# Altium schematic sheet DB10005_TopLevel.SchDoc(DB10005_TopLevel)
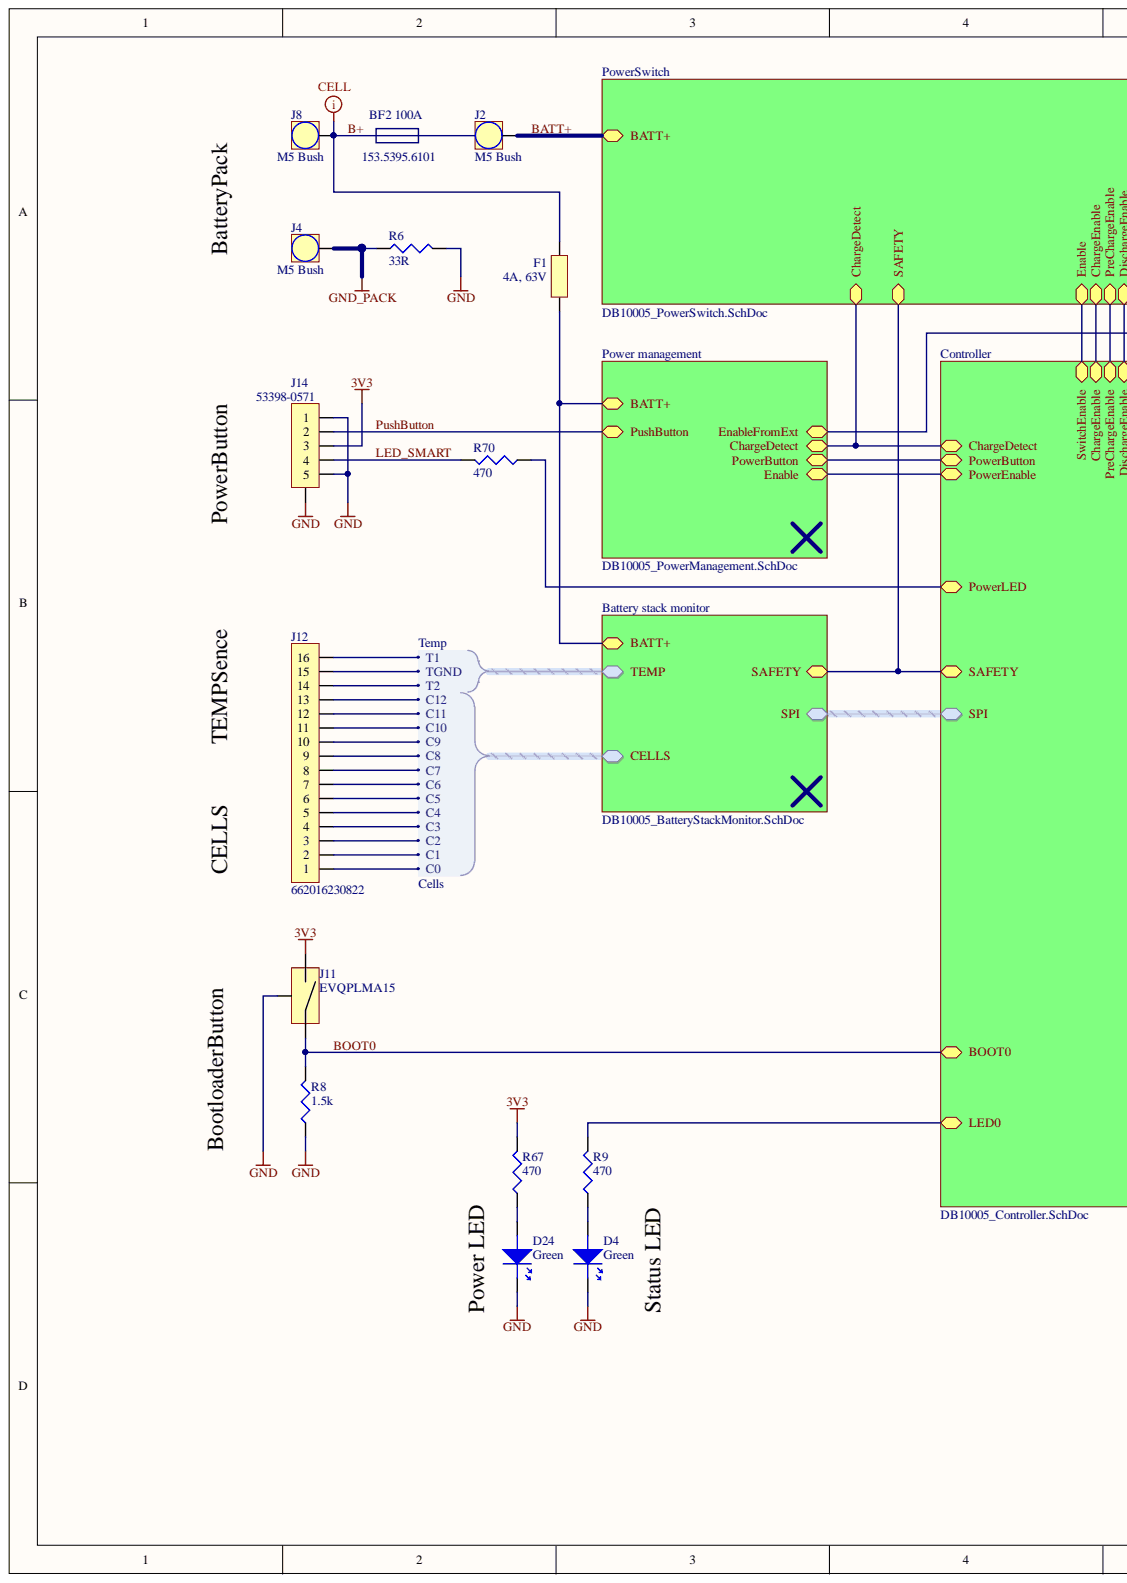
[diagram p0: DB10005_TopLevel.SchDoc, left]
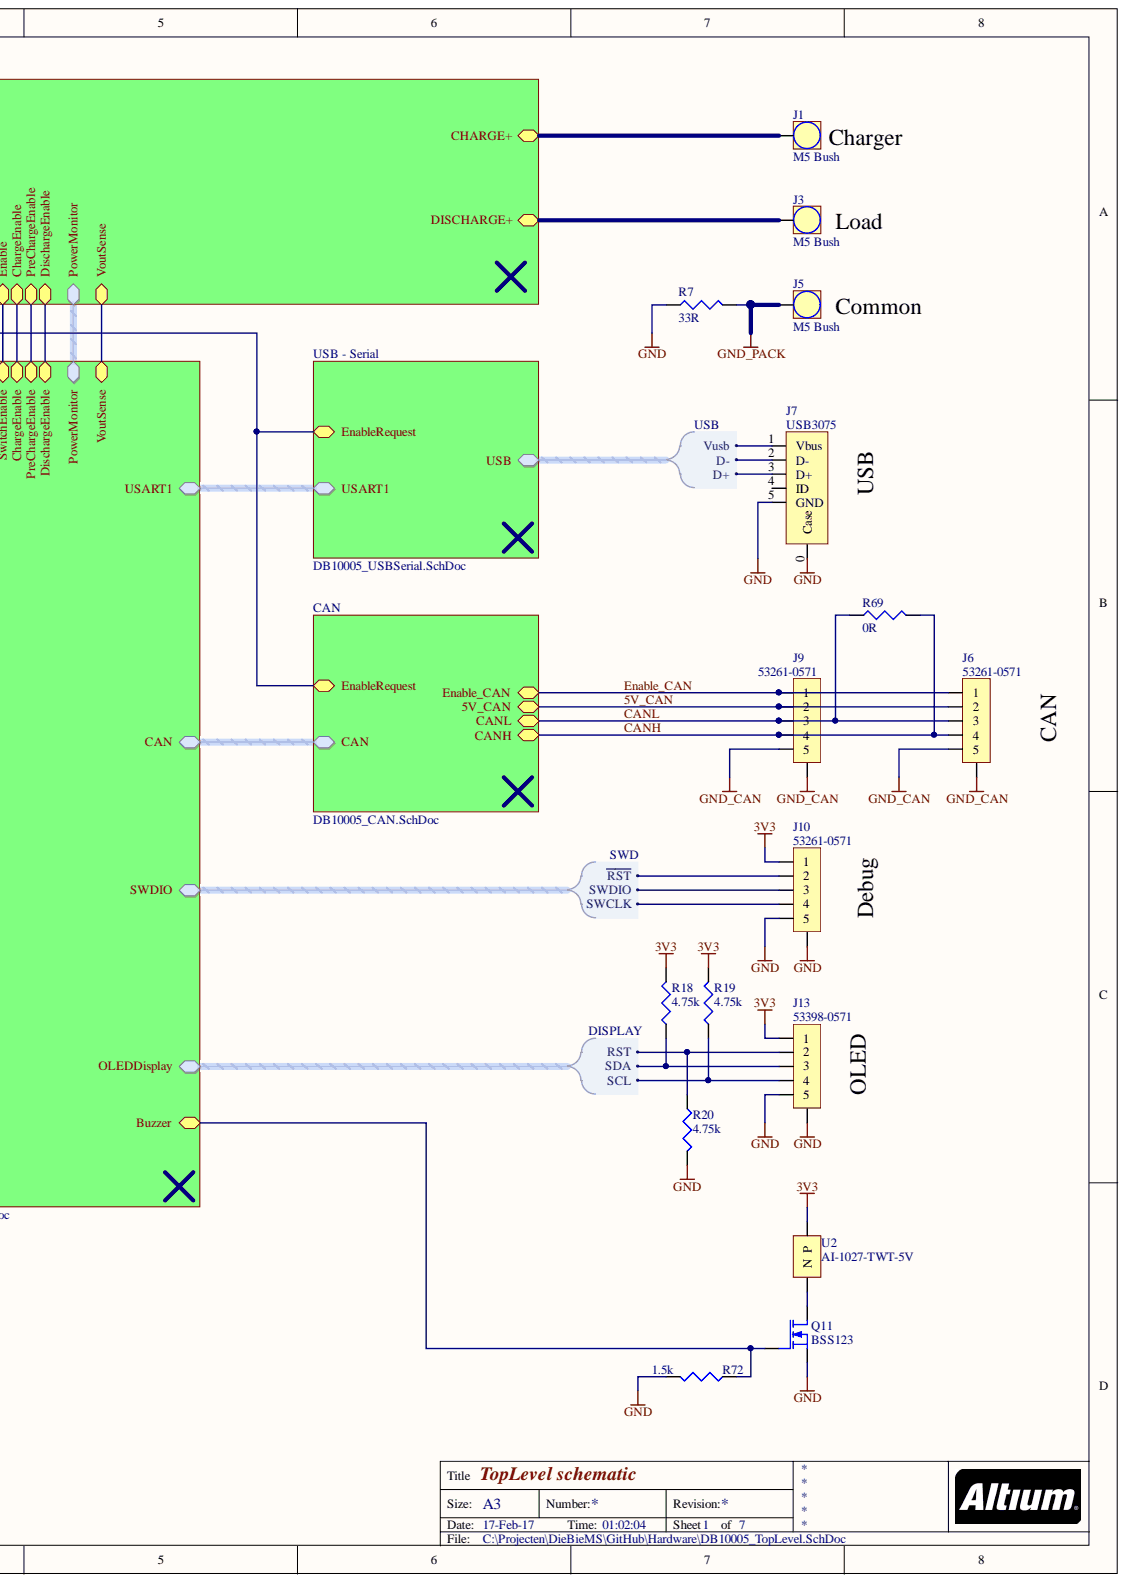
[diagram p1: DB10005_TopLevel.SchDoc, right]

## Parts
D4  Green  [D-0805]
D24  Green  [D-0805]
F1  4A, 63V  [F1206]
J1  M5 Bush  [7460408_HAL Surface]
J2  M5 Bush  [7460408_HAL Surface]
J3  M5 Bush  [7460408_HAL Surface]
J4  M5 Bush  [7460408_HAL Surface]
J5  M5 Bush  [7460408_HAL Surface]
J6  53261-0571  [53261-0571]
J7  USB3075  [USB3075 - NOSLOT]
J8  M5 Bush  [7460408_HAL Surface]
J9  53261-0571  [53261-0571]
J10  53261-0571  [53261-0571]
J11  EVQPLMA15  [EVQPLM]
J12  662016230822  [662016230822]
J13  53398-0571  [53398-0571]
J14  53398-0571  [53398-0571]
Q11  BSS123  [SOT-23]
R6  33R  [2512]
R7  33R  [2512]
R8  1.5k  [0402]
R9  470  [0402]
R18  4.75k  [0402]
R19  4.75k  [0402]
R20  4.75k  [0402]
R67  470  [0402]
R69  0R  [0805]
R70  470  [0402]
R72  1.5k  [0402]
U2  AI-1027-TWT-5V  [AI-1027-TWT]

## Nets
3V3: J10.1 J11.2 J13.1 J14.3 R18.2 R19.2 R67.2 U2.P
GND: D4.K D24.K J7.0(Case) J7.5(GND) J10.0 J10.5 J11.0 J13.0 J13.5 J14.0 J14.1 J14.5 Q11.S R6.2 R7.2 R8.1 R20.2 R72.2
GND_CAN: J6.0 J6.5 J9.0 J9.5
GND_PACK: J4.1 J5.1 R6.1 R7.1
5V_CAN: J6.2 J9.2
B+: F1.2 J8.1
BATT+: J2.1
BOOT0: J11.1 R8.2
CANH: J6.4 J9.4 R69.2
CANL: J6.3 J9.3 R69.1
ENABLE_CAN: J6.1 J9.1
LED_SMART: J14.4 R70.2
PUSHBUTTON: J14.2
NetD4_A: D4.A R9.1
NetD24_A: D24.A R67.1
NetF1_1: F1.1
NetJ1_1: J1.1
NetJ3_1: J3.1
NetJ7_1: J7.1(Vbus)
NetJ7_2: J7.2(D-)
NetJ7_3: J7.3(D+)
NetJ7_4: J7.4(ID)
NetJ10_2: J10.2
NetJ10_3: J10.3
NetJ10_4: J10.4
NetJ12_1: J12.1
NetJ12_2: J12.2
NetJ12_3: J12.3
NetJ12_4: J12.4
NetJ12_5: J12.5
NetJ12_6: J12.6
NetJ12_7: J12.7
NetJ12_8: J12.8
NetJ12_9: J12.9
NetJ12_10: J12.10
NetJ12_11: J12.11
NetJ12_12: J12.12
NetJ12_13: J12.13
NetJ12_14: J12.14
NetJ12_15: J12.15
NetJ12_16: J12.16
NetJ13_2: J13.2 R20.1
NetJ13_3: J13.3 R18.1
NetJ13_4: J13.4 R19.1
NetQ11_D: Q11.D U2.N
NetQ11_G: Q11.G R72.1
NetR9_2: R9.2
NetR70_1: R70.1
CAN.RXD:
CAN.TXD:
CHARGEDETECT:
CHARGEENABLE:
DISCHARGEENABLE:
ENABLEREQUEST:
N00290:
N00291:
N00292:
N00293:
N00294:
N00295:
N00296:
N00297:
N00298:
N00299:
N00300:
N00301:
N00302:
POWERBUTTON:
POWERENABLE:
POWERMONITOR.INT:
POWERMONITOR.SCL:
POWERMONITOR.SDA:
PRECHARGEENABLE:
SAFETY:
SPI.MISO:
SPI.MOSI:
SPI.~{SS}:
SPI.SCK:
SWITCHENABLE:
USART1.RXD:
USART1.TXD:
VOUTSENSE:

## Library data of the parts
D4 D24 = KP-2012SGC: Led, Green, Li:12mcd, Vf:2.2V, If:0.02A; Brand: KingBright; Case Style: 0805; Forward Current: 0.02A; Forward Voltage: 2.2V; Luminous Intensity: 12mcd; Brand Number: KP-2012SGC; Component Kind: Standard; Library Name: AR_Misc.IntLib; Order Code Supplier: 1318243; Price: 0,06; Supplier: Farnell; Temperature Range: -30°C to +85°C
F1 = MC12CT 004: Fuse, Slow Blow, 4A, 24V, T:-55°C +125°C; Brand: MULTICOMP; Prijs: 0,161; Brand Number: MC12CT 004; Component Kind: Standard; Library Name: AR_Misc.IntLib; Order Code Supplier: 1841066; Supplier: Farnell
J1-J5 J8 = 7460408: Bush with Circumference M5; Brand: Wurth; Case Style: M5Bush; Brand Number: 7460408; Component Kind: Standard; Library Name: AR_Connectors.IntLib; Order Code Supplier: 1821279; Price: 4,17; Supplier: Farnell
J6 J9 J10 = 53261-0571: PicoBlade 53261 Series, 5Pin, Right Angle; Brand: MOLEX; Pitch: 1.25mm; Brand Number: 53261-0571; Case Style: 53261-0571; Component Kind: Standard; Library Name: AR_Connectors.IntLib; Order Code Supplier: 1125360; Price: 1,20; Supplier: Farnell
J7 = USB3075: USB 2.0 MICRO B, RCPT, 5POS, SMT; Brand: Global Connector Technology; Brand Number: USB3075-30-A; Case Style: Micro B SMT; Pitch: 0.8mm; Component Kind: Standard; Library Name: AR_Connectors.IntLib; Order Code Supplier: 2443116; Price: 0,313; Supplier: Farnell
J11 = EVQPLMA15: SWITCH, TACT, 4.9MM, SMD, 2.6N; Brand: PANASONIC ELECTRONIC COMPONENTS; Brand Number: EVQPLMA15; Component Kind: Standard; Library Name: AR_Misc.IntLib; Order Code Supplier: 2079484; Price: 0,169; Supplier: Farnell
J12 = 662016230822: WR-MPC3 Series, Surface Mount, Header, 16 Contacts, 3 mm; Brand: Wurth; Case Style: 3mm BoxHeader; Pitch: 3mm; Brand Number: 662016230822; Component Kind: Standard; Library Name: AR_Connectors.IntLib; Order Code Supplier: 2113471; Price: 2,67; Supplier: Farnell
J13 J14 = 53398-0571: PicoBlade 53398 Series, 5Pin, Right Angle; Brand: MOLEX; Pitch: 1.25mm; Brand Number: 53398-0571; Case Style: 53398-0571; Component Kind: Standard; Library Name: AR_Connectors.IntLib; Order Code Supplier: 1125354; Price: 1,55; Supplier: Farnell
Q11 = BSS123: Mosfet, N-channel, Vds:100V, Id:0.15A, Vgs-th:2.8V, Rds-on:3.5ohm; Brand: NXP; Drain Current: 0.15A; Drain Source Voltage: 100V; Gate Source Voltage: 20V; On Resistance: 3.5ohm; Threshold Voltage: 2.8V; Brand Number: BSS123; Case Style: SOT-23; Component Kind: Standard; Library Name: AR_Mosfets.IntLib; Order Code Supplier: 1510764; Price: 0,082; Supplier: Farnell; Temperature Range: -55°C to +150°C; Transistor Polarity: N-channel
R6 R7 = 33/2512/2000W/250V: Resistor, 33R, 1%, 2W, 200V, 200PPM, 2512; Brand: TE CONNECTIVITY / CGS; Brand Number: 352133RFT; Voltage Rating: 250V; Case Style: 2512; Component Kind: Standard; Library Name: AR_Resistors_SMD.IntLib; Order Code Supplier: 2117464; Power Rating: 2W; Price: 0,15; Resistance: 33R; Supplier: Farnell; Temperature Coefficient: ± 200PPM; Temperature Range: -55°C to +125°C
R8 R72 = 1.5k/0402/0063W/50V: Resistor, 1.5k, 0.1%, 0.0625W, 50V, 100PPM, 0402; Brand: VISHAY DRALORIC; Brand Number: CRCW04021K50FKED; Power Rating: 0.063W; Case Style: 0402; Component Kind: Standard; Library Name: AR_Resistors_SMD.IntLib; Order Code Supplier: 2121624; Price: 0,0054; Resistance: 1.5k; Supplier: Farnell; Temperature Coefficient: ± 100PPM; Temperature Range: -55°C to +125°C; Voltage Rating: 50V
R9 R67 R70 = 470/0402/0063W/50V: Resistor, 470, 1%, 0.0625W, 50V, 100PPM, 0402; Brand: VISHAY DRALORIC; Brand Number: CRG0402F470R; Power Rating: 0.063W; Case Style: 0402; Component Kind: Standard; Library Name: AR_Resistors_SMD.IntLib; Order Code Supplier: 2331533; Price: 0,0099; Resistance: 470; Supplier: Farnell; Temperature Coefficient: ± 100PPM; Temperature Range: -55°C to +125°C; Voltage Rating: 50V
R18-R20 = 4.75k/0402/0063W/50V: Resistor, 4.75k, 0.1%, 0.0625W, 50V, 100PPM, 0402; Brand: VISHAY DRALORIC; Brand Number: CRCW04024K75FKED; Power Rating: 0.063W; Case Style: 0402; Component Kind: Standard; Library Name: AR_Resistors_SMD.IntLib; Order Code Supplier: 2121689; Price: 0,0006; Resistance: 4.75k; Supplier: Farnell; Temperature Coefficient: ± 100PPM; Temperature Range: -55°C to +125°C; Voltage Rating: 50V
R69 = 0/0805/0100W/150V: Resistor, 0R, 0.1%, 0.1W, 150V, 200PPM, 0805; Brand: MULTICOMP; Brand Number: MC 0.1W 0805 0R; Case Style: 0805; Component Kind: Standard; Library Name: AR_Resistors_SMD.IntLib; Order Code Supplier: 9333681; Power Rating: 0.1W; Price: 0,038; Resistance: 0R; Supplier: Farnell; Temperature Coefficient: ± 200PPM; Temperature Range: -55°C to +125°C; Voltage Rating: 150V
U2 = AI-1027-TWT-5V-2-R: EM 5VDC 2300Hz 82dBA Continuous Top Fire; Brand: PUI Audio; Brand Number: AI-1027-TWT-5V-2-R; Case Style: AI-1027-TWT-5V-2-R; Component Kind: Standard; Library Name: AR_Misc.IntLib; Order Code Supplier: 665-AI1027TWT5V2R; Price: 1,73; Supplier: Farnell
